# Altium schematic sheet LTE Modem.SchDoc
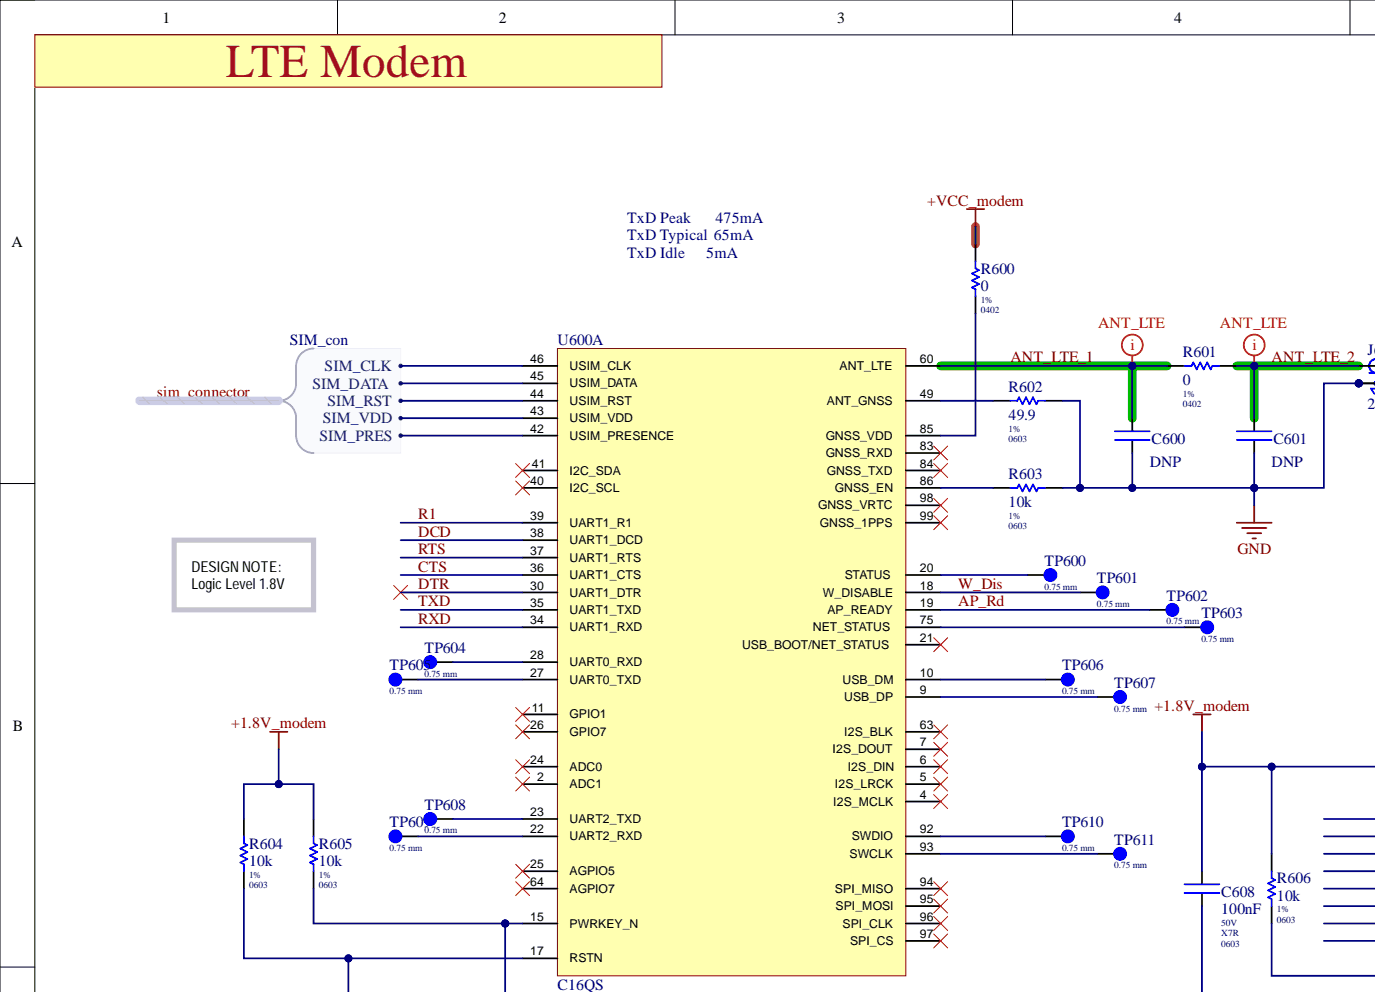
[diagram p0: LTE Modem.SchDoc, top left]
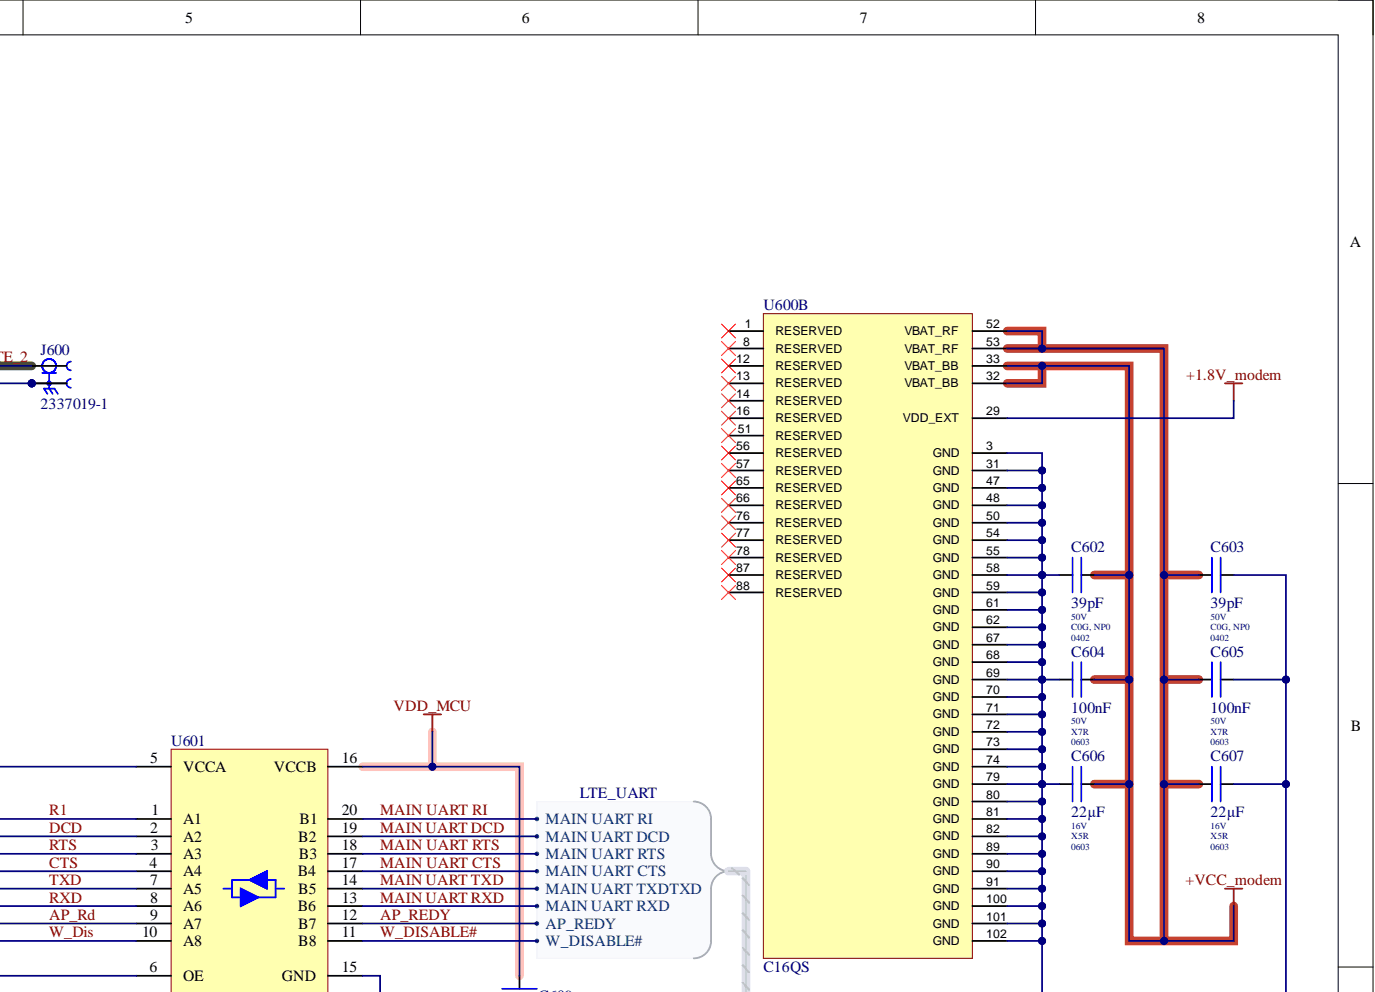
[diagram p1: LTE Modem.SchDoc, top right]
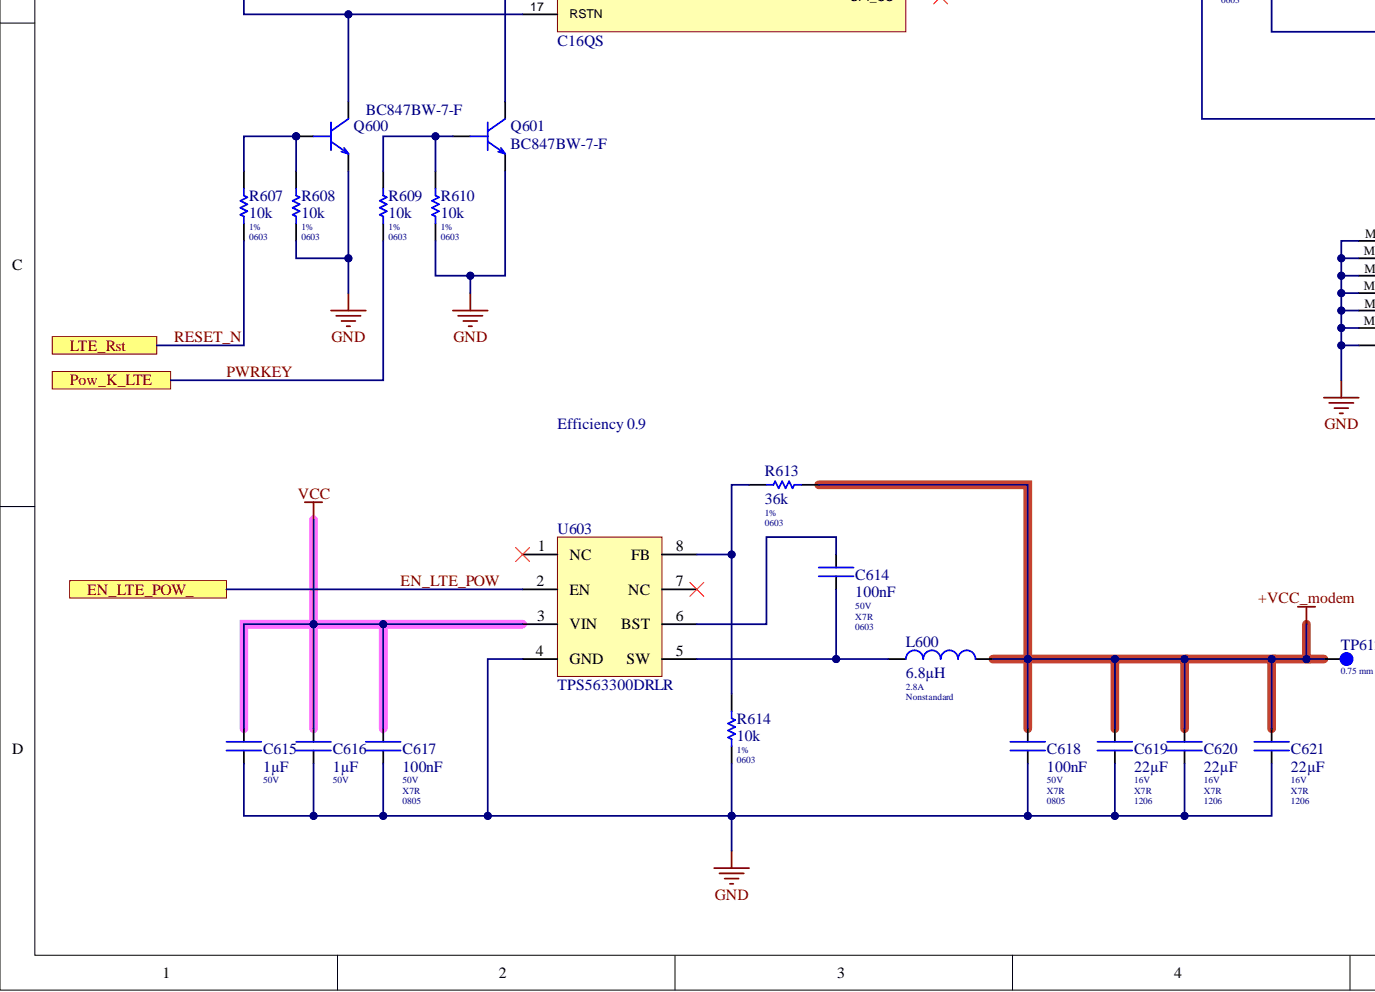
[diagram p2: LTE Modem.SchDoc, bottom left]
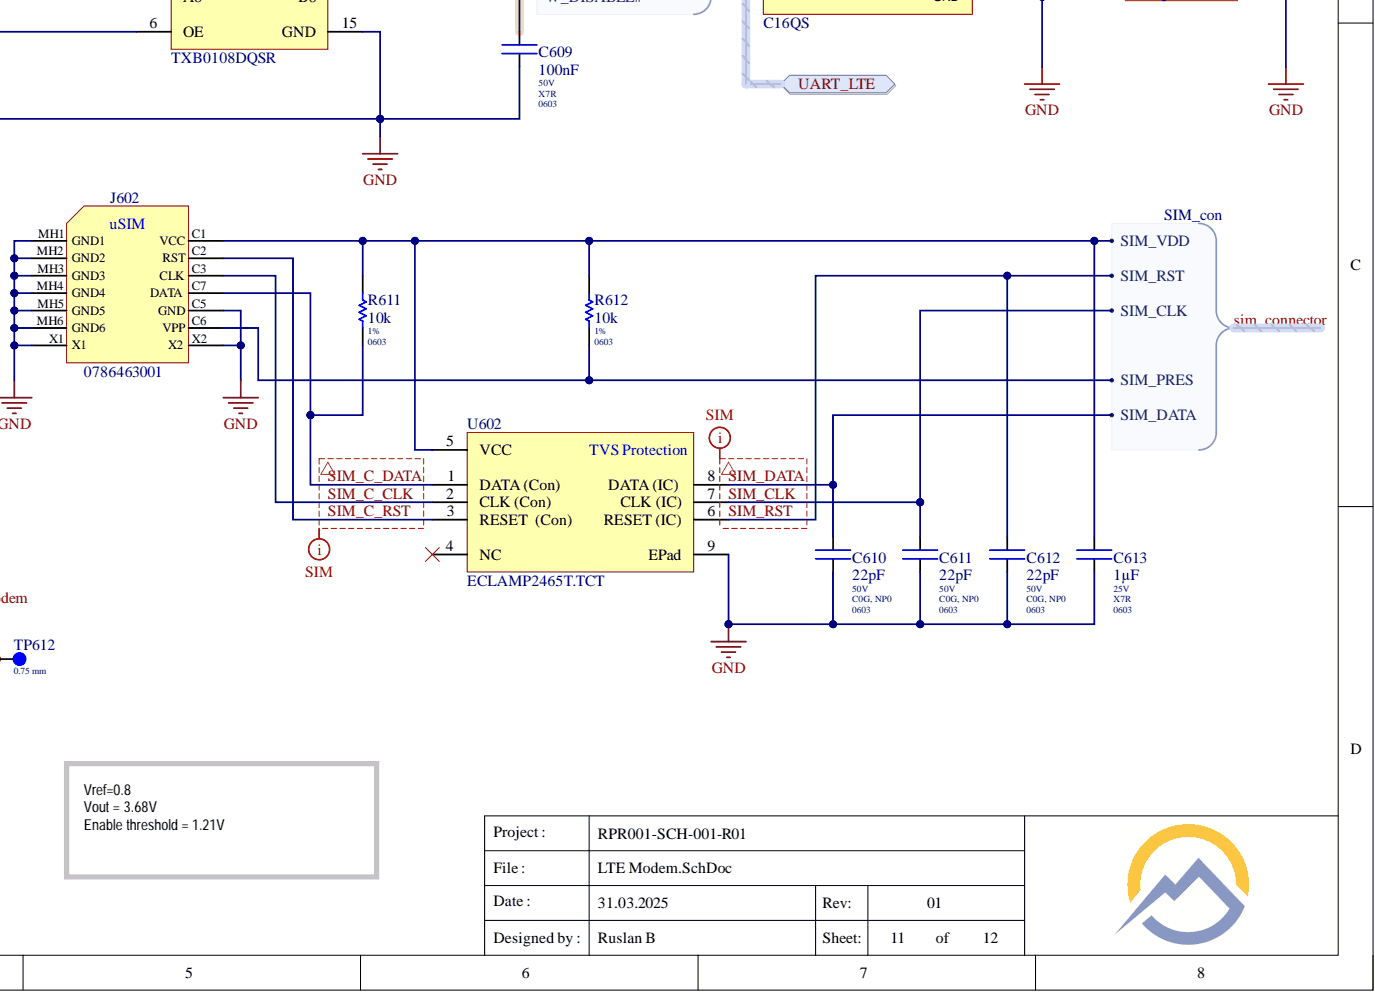
[diagram p3: LTE Modem.SchDoc, bottom right]

## Parts
C600  Assembly: DNP  [CAP_0402]  (hidden comment: Cap TBD, , 0402,)
C601  Assembly: DNP  [CAP_0402]  (hidden comment: Cap TBD, , 0402,)
C602  value 39pF  Voltage Rating: 50V  [B_Cap_0402_KEM]  (hidden comment: C0402C390J5GACTU)
C603  value 39pF  Voltage Rating: 50V  [B_Cap_0402_KEM]  (hidden comment: C0402C390J5GACTU)
C604  value 100nF  Voltage Rating: 50V  [B_Cap_0603_KEM]  (hidden comment: GCM188R71H104KA57D)
C605  value 100nF  Voltage Rating: 50V  [B_Cap_0603_KEM]  (hidden comment: GCM188R71H104KA57D)
C606  value 22µF  Case/Package: 0603  Dielectric: X5R  Voltage Rating: 16V  [B_Cap_0603_KEM]  (hidden comment: CL10A226MO7JZNC)
C607  value 22µF  Case/Package: 0603  Dielectric: X5R  Voltage Rating: 16V  [B_Cap_0603_KEM]  (hidden comment: CL10A226MO7JZNC)
C608  value 100nF  Case/Package: 0603  Dielectric: X7R  Voltage Rating: 50V  [B_Cap_0603_KEM]  (hidden comment: GCM188R71H104KA57D)
C609  value 100nF  Case/Package: 0603  Dielectric: X7R  Voltage Rating: 50V  [B_Cap_0603_KEM]  (hidden comment: GCM188R71H104KA57D)
C610  value 22pF  Case/Package: 0603  Dielectric: C0G, NP0  Voltage Rating: 50V  [B_Cap_0603_KEM]  (hidden comment: 06035A220JAT2A)
C611  value 22pF  Case/Package: 0603  Dielectric: C0G, NP0  Voltage Rating: 50V  [B_Cap_0603_KEM]  (hidden comment: 06035A220JAT2A)
C612  value 22pF  Case/Package: 0603  Dielectric: C0G, NP0  Voltage Rating: 50V  [B_Cap_0603_KEM]  (hidden comment: 06035A220JAT2A)
C613  value 1µF  Case/Package: 0603  Dielectric: X7R  Voltage Rating: 25V  [B_Cap_0603_KEM]  (hidden comment: CL10B105KA8NNNC)
C614  value 100nF  Case/Package: 0603  Dielectric: X7R  Voltage Rating: 50V  [B_Cap_0603_KEM]  (hidden comment: GCM188R71H104KA57D)
C615  value 1µF  Voltage Rating: 50V  [B_Cap_0805_KEM]  (hidden comment: CL21B105KBFNNNG)
C616  value 1µF  Voltage Rating: 50V  [B_Cap_0805_KEM]  (hidden comment: CL21B105KBFNNNG)
C617  value 100nF  Case/Package: 0805  Dielectric: X7R  Voltage Rating: 50V  [B_Cap_0805_KEM]  (hidden comment: CC0805KRX7R9BB104)
C618  value 100nF  Case/Package: 0805  Dielectric: X7R  Voltage Rating: 50V  [B_Cap_0805_KEM]  (hidden comment: CC0805KRX7R9BB104)
C619  value 22µF  Case/Package: 1206  Dielectric: X7R  Voltage Rating: 16V  [B_Cap_1206_KEM]  (hidden comment: EMK316BB7226ML-T)
C620  value 22µF  Case/Package: 1206  Dielectric: X7R  Voltage Rating: 16V  [B_Cap_1206_KEM]  (hidden comment: EMK316BB7226ML-T)
C621  value 22µF  Case/Package: 1206  Dielectric: X7R  Voltage Rating: 16V  [B_Cap_1206_KEM]  (hidden comment: EMK316BB7226ML-T)
J600  2337019-1  [TE_2337019-1]
J602  0786463001  [0786463001-Footprint-1]
L600  value 6.8µH  Case/Package: Nonstandard  Current Rating: 2.8A  [SRN6045]  (hidden comment: SRN6045-6R8Y)
Q600  BC847BW-7-F  [SC70(SOT323)-3-2.1x1.24]
Q601  BC847BW-7-F  [SC70(SOT323)-3-2.1x1.24]
R600  value 0  Case/Package: 0402  Tolerance: 1%  [Res_0402_YAG]  (hidden comment: RC0402JR-070RL)
R601  value 0  Tolerance: 1%  [Res_0402_YAG]  (hidden comment: RC0402JR-070RL)
R602  value 49.9  Tolerance: 1%  [Res_0603_YAG]  (hidden comment: RC0603FR-0749R9L)
R603  value 10k  Case/Package: 0603  Tolerance: 1%  [Res_0603_YAG]  (hidden comment: RC0603FR-0710KL)
R604  value 10k  Case/Package: 0603  Tolerance: 1%  [Res_0603_YAG]  (hidden comment: RC0603FR-0710KL)
R605  value 10k  Case/Package: 0603  Tolerance: 1%  [Res_0603_YAG]  (hidden comment: RC0603FR-0710KL)
R606  value 10k  Case/Package: 0603  Tolerance: 1%  [Res_0603_YAG]  (hidden comment: RC0603FR-0710KL)
R607  value 10k  Case/Package: 0603  Tolerance: 1%  [Res_0603_YAG]  (hidden comment: RC0603FR-0710KL)
R608  value 10k  Case/Package: 0603  Tolerance: 1%  [Res_0603_YAG]  (hidden comment: RC0603FR-0710KL)
R609  value 10k  Case/Package: 0603  Tolerance: 1%  [Res_0603_YAG]  (hidden comment: RC0603FR-0710KL)
R610  value 10k  Case/Package: 0603  Tolerance: 1%  [Res_0603_YAG]  (hidden comment: RC0603FR-0710KL)
R611  value 10k  Case/Package: 0603  Tolerance: 1%  [Res_0603_YAG]  (hidden comment: RC0603FR-0710KL)
R612  value 10k  Case/Package: 0603  Tolerance: 1%  [Res_0603_YAG]  (hidden comment: RC0603FR-0710KL)
R613  value 36k  Case/Package: 0603  Tolerance: 1%  [Res_0603_YAG]  (hidden comment: RC0603FR-0736KL)
R614  value 10k  Case/Package: 0603  Tolerance: 1%  [Res_0603_YAG]  (hidden comment: RC0603FR-0710KL)
TP600  0.75 mm  [0.75mm]  (no BOM)
TP601  0.75 mm  [0.75mm]  (no BOM)
TP602  0.75 mm  [0.75mm]  (no BOM)
TP603  0.75 mm  [0.75mm]  (no BOM)
TP604  0.75 mm  [0.75mm]  (no BOM)
TP605  0.75 mm  [0.75mm]  (no BOM)
TP606  0.75 mm  [0.75mm]  (no BOM)
TP607  0.75 mm  [0.75mm]  (no BOM)
TP608  0.75 mm  [0.75mm]  (no BOM)
TP609  0.75 mm  [0.75mm]  (no BOM)
TP610  0.75 mm  [0.75mm]  (no BOM)
TP611  0.75 mm  [0.75mm]  (no BOM)
TP612  0.75 mm  [0.75mm]  (no BOM)
U600  C16QS  [C16QS]  (parts A,B)
U601  TXB0108DQSR  [TXB0108DQSR-Footprint-1]
U602  ECLAMP2465T.TCT  [ECLAMP2465T.TCT-Footprint-1]
U603  TPS563300DRLR  [SOT-583]

## Ports
EN_LTE_POW_, LTE_Rst, Pow_K_LTE, UART_LTE, UART_LTE.AP_REDY, UART_LTE.MAIN UART CTS, UART_LTE.MAIN UART DCD, UART_LTE.MAIN UART RI, UART_LTE.MAIN UART RTS, UART_LTE.MAIN UART RXD, UART_LTE.MAIN UART TXDTXD, UART_LTE.W_DISABLE#

## Nets
+1.8V_modem: C608.1 R604.2 R605.2 R606.2 U600.29(VDD_EXT) U601.5(VCCA)
+VCC_modem: C602.1 C603.2 C604.1 C605.2 C606.1 C607.2 C618.2 C619.2 C620.2 C621.2 L600.2 R600.2 R613.2 TP612.1 U600.32(VBAT_BB) U600.33(VBAT_BB) U600.52(VBAT_RF) U600.53(VBAT_RF)
GND: C600.1 C601.1 C602.2 C603.1 C604.2 C605.1 C606.2 C607.1 C608.2 C609.2 C610.1 C611.1 C612.1 C613.1 C615.2 C616.2 C617.1 C618.1 C619.1 C620.1 C621.1 J600.G1 J600.G2 J602.C5(GND) J602.MH1(GND1) J602.MH2(GND2) J602.MH3(GND3) J602.MH4(GND4) J602.MH5(GND5) J602.MH6(GND6) J602.X1 J602.X2 Q600.2 Q601.2 R602.1 R603.1 R608.1 R610.1 R614.1 U600.3(GND) U600.31(GND) U600.47(GND) U600.48(GND) U600.50(GND) U600.54(GND) U600.55(GND) U600.58(GND) U600.59(GND) U600.61(GND) U600.62(GND) U600.67(GND) U600.68(GND) U600.69(GND) U600.70(GND) U600.71(GND) U600.72(GND) U600.73(GND) U600.74(GND) U600.79(GND) U600.80(GND) U600.81(GND) U600.82(GND) U600.89(GND) U600.90(GND) U600.91(GND) U600.100(GND) U600.101(GND) U600.102(GND) U601.15(GND) U602.9(EPad) U603.4(GND)
VCC: C615.1 C616.1 C617.2 U603.3(VIN)
VDD_MCU: C609.1 U601.16(VCCB)
ANT_LTE_1: C600.2 R601.1 U600.60(ANT_LTE)
ANT_LTE_2: C601.2 J600.1 R601.2
AP_Rd: TP602.1 U600.19(AP_READY) U601.9(A7)
AP_REDY: U601.12(B7) ; port UART_LTE
CTS: U600.36(UART1_CTS) U601.4(A4)
DCD: U600.38(UART1_DCD) U601.2(A2)
DTR: U600.30(UART1_DTR)
EN_LTE_POW: U603.2(EN) ; port EN_LTE_POW_
MAIN UART CTS: U601.17(B4) ; port UART_LTE
MAIN UART DCD: U601.19(B2) ; port UART_LTE
MAIN UART RI: U601.20(B1) ; port UART_LTE
MAIN UART RTS: U601.18(B3) ; port UART_LTE
MAIN UART RXD: U601.13(B6) ; port UART_LTE
MAIN UART TXD: U601.14(B5) ; port UART_LTE
PWRKEY: R609.1 ; port Pow_K_LTE
R1: U600.39(UART1_R1) U601.1(A1)
RESET_N: R607.1 ; port LTE_Rst
RTS: U600.37(UART1_RTS) U601.3(A3)
RXD: U600.34(UART1_RXD) U601.8(A6)
SIM_C_CLK: J602.C3(CLK) U602.2(CLK_(Con))
SIM_C_DATA: J602.C7(DATA) R611.2 U602.1(DATA_(Con))
SIM_C_RST: J602.C2(RST) U602.3(RESET)
SIM_CLK: C611.2 U600.46(USIM_CLK) U602.7(CLK_(IC))
sim_connector.SIM_DATA: C610.2 U600.45(USIM_DATA) U602.8(DATA_(IC))
sim_connector.SIM_PRES: J602.C6(VPP) R612.2 U600.42(USIM_PRESENCE)
sim_connector.SIM_RST: C612.2 U600.44(USIM_RST) U602.6(RESET_(IC))
sim_connector.SIM_VDD: C613.2 J602.C1(VCC) R611.1 R612.1 U600.43(USIM_VDD) U602.5(VCC)
TXD: U600.35(UART1_TXD) U601.7(A5)
W_Dis: TP601.1 U600.18(W_DISABLE) U601.10(A8)
W_DISABLE#: U601.11(B8) ; port UART_LTE
NetC614_1: C614.1 L600.1 U603.5(SW)
NetC614_2: C614.2 U603.6(BST)
NetQ600_1: Q600.1 R607.2 R608.2
NetQ600_3: Q600.3 R604.1 U600.17(RSTN)
NetQ601_1: Q601.1 R609.2 R610.2
NetQ601_3: Q601.3 R605.1 U600.15(PWRKEY_N)
NetR600_1: R600.1 U600.85(GNSS_VDD)
NetR602_2: R602.2 U600.49(ANT_GNSS)
NetR603_2: R603.2 U600.86(GNSS_EN)
NetR606_1: R606.1 U601.6(OE)
NetR613_1: R613.1 R614.2 U603.8(FB)
NetTP600_1: TP600.1 U600.20(STATUS)
NetTP603_1: TP603.1 U600.75(NET_STATUS)
NetTP604_1: TP604.1 U600.28(UART0_RXD)
NetTP605_1: TP605.1 U600.27(UART0_TXD)
NetTP606_1: TP606.1 U600.10(USB_DM)
NetTP607_1: TP607.1 U600.9(USB_DP)
NetTP608_1: TP608.1 U600.23(UART2_TXD)
NetTP609_1: TP609.1 U600.22(UART2_RXD)
NetTP610_1: TP610.1 U600.92(SWDIO)
NetTP611_1: TP611.1 U600.93(SWCLK)

Not connected: U600 1(RESERVED) 2(ADC1) 4(I2S_MCLK) 5(I2S_LRCK) 6(I2S_DIN) 7(I2S_DOUT) 8(RESERVED) 11(GPIO1) 12(RESERVED) 13(RESERVED) 14(RESERVED) 16(RESERVED) 21(USB_BOOT/NET_STATUS) 24(ADC0) 25(AGPIO5) 26(GPIO7) 40(I2C_SCL) 41(I2C_SDA) 51(RESERVED) 56(RESERVED) 57(RESERVED) 63(I2S_BLK) 64(AGPIO7) 65(RESERVED) 66(RESERVED) 76(RESERVED) 77(RESERVED) 78(RESERVED) 83(GNSS_RXD) 84(GNSS_TXD) 87(RESERVED) 88(RESERVED) 94(SPI_MISO) 95(SPI_MOSI) 96(SPI_CLK) 97(SPI_CS) 98(GNSS_VRTC) 99(GNSS_1PPS); U602 4(NC); U603 1(NC) 7(NC)

## Library data of the parts
C600 C601 = CMP-CAP-00000-4: SMD capacitor; Component Kind: Standard; Library Name: <Company> Server; Manufacturer 1: TBD; Manufacturer Part Number 1: TBD
C602 C603 = CMP-CAP-CER-00195-16: CAP CER 39PF 50V C0G/NP0 0402; Manufacturer 1: KEMET; Dielectric: C0G, NP0; Tolerance: ±5%; Case/Package: 0402; Component Kind: Standard; ComponentLink1Description: Datasheet; ComponentLink1URL: https://api.kemet.com/component-edge/download/datasheet/C0402C390J5GACTU.pdf; Library Name: <Company> Server; Manufacturer Part Number 1: C0402C390J5GACTU
C604 C605 C608 C609 C614 = CMP-CAP-CER-00027-13: CAP CER 0.1UF 50V X7R 0603; Manufacturer 1: Murata; Tolerance: ±10%; Component Kind: Standard; ComponentLink1Description: Datasheet; ComponentLink1URL: https://search.murata.co.jp/Ceramy/image/img/A01X/G101/ENG/GCM188R71H104KA57-01.pdf; Library Name: <Company> Server; Manufacturer Part Number 1: GCM188R71H104KA57D
  C604 C605: Case/Package: 0603; Dielectric: X7R
C606 C607 = CMP-CAP-CER-00165-12: CAP CER 22UF 16V X5R 0603; Manufacturer 1: Samsung; Tolerance: ±20%; Component Kind: Standard; ComponentLink1Description: Datasheet; ComponentLink1URL: https://www.samsungsem.com/resources/file/global/support/product_catalog/MLCC.pdf; Library Name: <Company> Server; Manufacturer Part Number 1: CL10A226MO7JZNC
C610-C612 = CMP-CAP-CER-00161-12: CAP CER 22PF 50V C0G/NP0 0603; Manufacturer 1: Kyocera AVX; Tolerance: ±5%; Component Kind: Standard; ComponentLink1Description: Datasheet; ComponentLink1URL: https://datasheets.kyocera-avx.com/C0GNP0-Dielectric.pdf; Library Name: <Company> Server; Manufacturer Part Number 1: 06035A220JAT2A
C613 = CMP-CAP-CER-00132-13: CL10B105KA8NNNC Samsung Ceramic Capacitor MLCC 1uF10% 25V X7R SMD 0603 (1608 Metric) RoHS; Manufacturer 1: Samsung Electro-Mechanics; Tolerance: 10%; Component Kind: Standard; Library Name: <Company> Server; Manufacturer Part Number 1: CL10B105KA8NNNC
C615 C616 = CMP-CAP-CER-00135-12: CAP CER 1UF 50V X7R 0805; Manufacturer 1: Samsung; Tolerance: ±10%; Component Kind: Standard; ComponentLink1Description: Datasheet; ComponentLink1URL: http://www.samsungsem.com/kr/support/product-search/mlcc/CL21B105KBFNNNG.jsp; Library Name: <Company> Server; Manufacturer Part Number 1: CL21B105KBFNNNG
C617 C618 = CMP-CAP-CER-00029-14: Multilayer Ceramic Capacitor, 0.1 uF, 50 V, ï¿½ 10%, X7R, 0805 [2012 Metric]; Manufacturer 1: YAGEO; Component Kind: Standard; Library Name: <Company> Server; Manufacturer Part Number 1: CC0805KRX7R9BB104; Tolerance: 10%
C619-C621 = CMP-CAP-CER-00168-12: CAP CER 22UF 16V X7R 1206; Manufacturer 1: Taiyo Yuden; Tolerance: ±20%; Component Kind: Standard; ComponentLink1Description: Datasheet; ComponentLink1URL: https://www.yuden.co.jp/productdata/catalog/mlcc06_e.pdf; Library Name: <Company> Server; Manufacturer Part Number 1: EMK316BB7226ML-T
J600 = CMP-2159-000000-3: RF Connector; Coaxial Connectors MicroCoax receptacle UMCC Gen 1; Manufacturer 1: TE Connectivity AMP Connectors; Impedance: 50R; Max Frequency: 6GHz; Availability: Unavailable; Component Kind: Standard; Component Type: Standard; Design Item ID: 2337019-1; Library Name: <Company> Server; Manufacturer Part Number 1: 2337019-1; MP: 2337019-1; Package: None; Pin Count: 3; Price: None; Source: 2337019-1.SchLib
J602 = CMP-CON-MEM-00005-4: CONN MICRO SIM CARD PUSH-PULL; Manufacturer 1: Molex; Manufacturer Part Number 1: 78646-3001; Number of Contacts: 6; Case/Package: Micro SIM; Component Kind: Standard; ComponentLink1Description: Datasheet; ComponentLink1URL: https://media.digikey.com/pdf/Data%20Sheets/Molex%20PDFs/micro-SIM_CardSockets(Hinged,PushPull).pdf; Library Name: <Company> Server; Number of Positions: 6; Number of Rows: 1
L600 = CMP-IND-00099-9: FIXED IND 6.8UH 2.8A 47.3MOHM SM; Manufacturer 1: Bourns; DC Resistance (DCR): 47.3m; Self Resonant Frequency: 20MHz; Shielding: Semi-Shielded; Tolerance: 30%; Component Kind: Standard; ComponentLink1Description: Datasheet; ComponentLink1URL: https://www.bourns.com/docs/Product-Datasheets/SRN6045.pdf; Library Name: <Company> Server; Manufacturer Part Number 1: SRN6045-6R8Y
Q600 Q601 = CMP-TRN-BIP-00007-7: TRANS NPN 45V 0.1A SC70-3; Manufacturer 1: Diodes; Max Collector Current: 100mA; Power Dissipation: 200mW; Transition Frequency: 300MHz; Case/Package: SC-70, SOT-323; Collector Emitter Breakdown Voltage: 45V; Component Kind: Standard; ComponentLink1Description: Datasheet; ComponentLink1URL: https://www.diodes.com/assets/Datasheets/ds30250.pdf; Library Name: <Company> Server; Manufacturer Part Number 1: BC847BW-7-F; Polarity: NPN
R600 R601 = CMP-RES-SMD-00137-12: RES SMD 0 OHM JUMPER 1/16W 0402; Manufacturer 1: Yageo; Temperature Coefficient: ±100ppm/℃; Component Kind: Standard; ComponentLink1Description: Datasheet; ComponentLink1URL: https://www.yageo.com/upload/media/product/productsearch/datasheet/rchip/PYu-RC_Group_51_RoHS_L_10.pdf; Library Name: <Company> Server; Manufacturer Part Number 1: RC0402JR-070RL
  R601: Case/Package: 0402
R602 = CMP-RES-SMD-00353-9: RES SMD 49.9 OHM 1% 1/10W 0603; Manufacturer 1: Yageo; Temperature Coefficient: ±100ppm/℃; Case/Package: 0603; Component Kind: Standard; ComponentLink1Description: Datasheet; ComponentLink1URL: http://www.yageo.com/documents/recent/PYu-RC_Group_51_RoHS_L_10.pdf; Library Name: <Company> Server; Manufacturer Part Number 1: RC0603FR-0749R9L
R603-R612 R614 = CMP-RES-SMD-00183-9: RES SMD 10K OHM 1% 1/10W 0603; Manufacturer 1: Yageo; Temperature Coefficient: ±100ppm/℃; Component Kind: Standard; ComponentLink1Description: Datasheet; ComponentLink1URL: https://www.yageo.com/upload/media/product/productsearch/datasheet/rchip/PYu-RC_Group_51_RoHS_L_10.pdf; Library Name: <Company> Server; Manufacturer Part Number 1: RC0603FR-0710KL
R613 = CMP-RES-SMD-00313-9: RES SMD 36K OHM 1% 1/10W 0603; Manufacturer 1: Yageo; Temperature Coefficient: ±100ppm/℃; Component Kind: Standard; ComponentLink1Description: Datasheet; ComponentLink1URL: https://www.yageo.com/upload/media/product/productsearch/datasheet/rchip/PYu-RC_Group_51_RoHS_L_11.pdf; Library Name: <Company> Server; Manufacturer Part Number 1: RC0603FR-0736KL
TP600-TP612 = CMP-MF-TP-00003-4: Test point 0.75 mm; Library Name: <Company> Server; Size: 0.75 mm
U600 = CMP-RAD-RF-00015-2: LTE C16QS Cavli CS16QS-WW-GNAN; Component Kind: Standard; Library Name: <Company> Server; Manufacturer: Cavli; Manufacturer Part Number: CS16QS-WW-GNAN
U601 = CMP-IC-INF-00005-3: 8-Bit Bidirectional Voltage-Level Shifter with Auto Direction Sensing and +/-15-kV ESD Protect 20-USON -40 to 85; Manufacturer 1: Texas Instruments; Data Rate: 100Mbps; Logic Function: Translator; Max Supply Voltage: 5.5V; Min Supply Voltage: 1.2V; Case/Package: 20-UFDFN; Channel Type: Bidirectional; Component Kind: Standard; Library Name: <Company> Server; Manufacturer Part Number 1: TXB0108DQSR
U602 = CMP-CPT-TVS-00069-5: Diode TVS Quad Bi-Dir 5V 8-Pin SLP EP T/R; Manufacturer 1: Semtech; ESD Protection: Yes; Leakage Current: 500nA; Polarity: Bidirectional; Case/Package: 8-XFDFN; Component Kind: Standard; Library Name: <Company> Server; Manufacturer Part Number 1: ECLAMP2465T.TCT; Reverse Standoff Voltage: 5V
U603 = CMP-POW-VOLR-SW-00040-4: 3.8-V to 28-V Input, 3-A synchronous buck converter in SOT-583 package 8-SOT-5X3 -40 to 150; Manufacturer 1: Texas Instruments; Component Kind: Standard; Library Name: <Company> Server; Manufacturer Part Number 1: TPS563300DRLR
